# Altium schematic sheet PWR.SchDoc
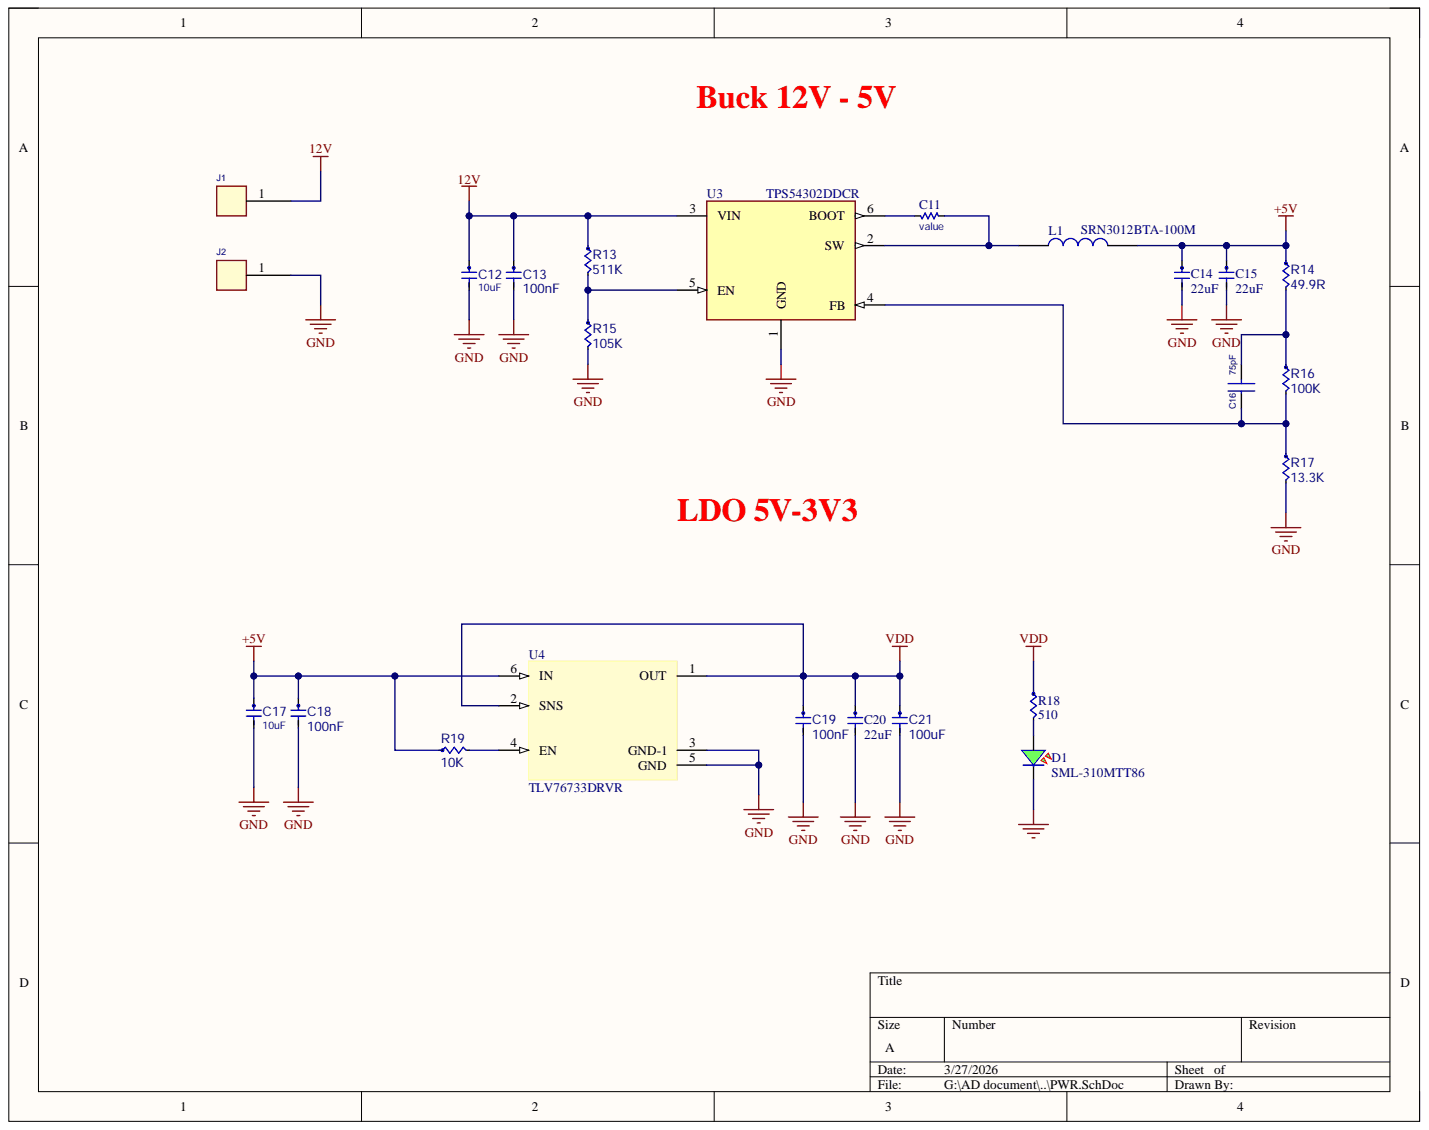
[diagram p0: PWR.SchDoc]

## Parts
C11  RES0402  [RES0402]  (value not drawn)
C12  Capacitance: 10uF  [CAP0603]  (hidden comment: GRM188R6YA106MA73D)
C13  Capacitance: 100nF  [CAP0402]  (hidden comment: CC0402KRX5R9BB104)
C14  Capacitance: 22uF  [CAP0402]  (hidden comment: GRM158R61A226ME15D)
C15  Capacitance: 22uF  [CAP0402]  (hidden comment: GRM158R61A226ME15D)
C16  75pF  [CAP0402]
C17  Capacitance: 10uF  [CAP0603]  (hidden comment: GRM188R6YA106MA73D)
C18  Capacitance: 100nF  [CAP0402]  (hidden comment: CC0402KRX5R9BB104)
C19  Capacitance: 100nF  [CAP0402]  (hidden comment: CC0402KRX5R9BB104)
C20  Capacitance: 22uF  [CAP0402]  (hidden comment: GRM158R61A226ME15D)
C21  Capacitance: 100uF  [CAP0805]  (hidden comment: CL21A107MQYNNWE)
D1  SML-310MTT86  [LED0603 RED]
J1  CON1  [ESC PAD 3X4]  (value not drawn)
J2  CON1  [ESC PAD 3X4]  (value not drawn)
L1  SRN3012BTA-100M  [SRN3012BTA-100M]
R13  511K  [RES0402]
R14  49.9R  [RES0402]
R15  105K  [RES0402]
R16  100K  [RES0402]
R17  13.3K  [RES0402]
R18  RT0402FRE07510RL  [RES0402]  (value not drawn)
R19  Resistance: 10K  [RES0402]  (hidden comment: CRCW040210K0JNED)
U3  TPS54302DDCR  [SOT95P280X110-6N - duplicate]
U4  TLV76733DRVR  [SON65P200X200X80-7N - duplicate]

## Nets
12V: C12.1 C13.1 J1.1 R13.1 U3.3(VIN)
+5V: C14.1 C15.1 C17.1 C18.1 L1.2 R14.1 R19.1 U4.6(IN)
GND: C12.2 C13.2 C14.2 C15.2 C17.2 C18.2 C19.2 C20.2 C21.2 D1.1 J2.1 R15.2 R17.2 U3.1(GND) U4.3(GND-1) U4.5(GND)
VDD: C19.1 C20.1 C21.1 R18.1 U4.1(OUT) U4.2(SNS)
NetC11_1: C11.1 U3.6(BOOT)
NetC11_2: C11.2 L1.1 U3.2(SW)
NetC16_1: C16.1 R16.2 R17.1 U3.4(FB)
NetC16_2: C16.2 R14.2 R16.1
NetD1_2: D1.2 R18.2
NetR13_2: R13.2 R15.1 U3.5(EN)
NetR19_2: R19.2 U4.4(EN)

## Library data of the parts
C11 = RES0402: RES 0402; MP: add later; Component Kind: Standard; Value: value; Library Name: RTR.SchLib
C12 C17 = CMP-01031-1: CAP CER 10UF 35V X5R 0603; Manufacturer 1: Murata Electronics; Tolerance: 20%; Case Code (Imperial): 0603; Case Code (Metric): 1608; Case/Package: 0603; Component Kind: Standard; Composition: Ceramic; Depth: 0.8mm; Dielectric: X5R; Height: 0.8mm; Lead Free: Lead Free; Length: 1.6mm; Manufacturer Part Number 1: GRM188R6YA106MA73D; Max Operating Temperature: 85°C; Min Operating Temperature: -55°C; Mount: Surface Mount; Number of Pins: 2; Package Quantity: 1; Packaging: Tape and Reel; REACH SVHC: No SVHC; Termination: SMD/SMT; Thickness: 0.031inch; Voltage: 35V; Voltage Rating: 35V; Voltage Rating (DC): 35V; Weight: 0.000222oz; Width: 0.031inch; Library Name: rtr.IntLib
C13 C18 C19 = CMP-00265-1: CAP CER 0.1UF 50V X5R 0402; Manufacturer: Yageo; Tolerance: 10%; Case/Package: 0402; Component Kind: Standard; Dielectric: X5R; Halogen Free: Halogen Free; Lead Free: Lead Free; Length: 0.039inch; Manufacturer 1: Yageo; Manufacturer Part Number: CC0402KRX5R9BB104; Manufacturer Part Number 1: CC0402KRX5R9BB104; Max Operating Temperature: 85°C; Min Operating Temperature: -55°C; Mount: Surface Mount; Packaging: Tape and Reel; Thickness: 0.022inch; Voltage Rating: 50V; Voltage Rating (DC): 50V; Width: 0.02inch; Library Name: rtr.IntLib
C14 C15 C20 = CMP-00520-1: CAP CER 22UF 10V X5R 0402; Manufacturer 1: TDK Corporation; Tolerance: 10%; Case Code (Imperial): 0402; Case Code (Metric): 1005; Case/Package: 0402; Component Kind: Standard; Depth: 0.5mm; Dielectric: X5R; Length: 1mm; Library Name: CH961_CARRIER.IntLib; Manufacturer Part Number 1: GRM158R61A226ME15D; Max Operating Temperature: 85°C; Min Operating Temperature: -55°C; Packaging: Tape and Reel; Radiation Hardening: No; REACH SVHC: No SVHC; Termination: SMD/SMT; Voltage: 10V; Voltage Rating: 10V
C16 = CAP_Dup1_16: CAP CER 75PF 25V C0G/NP0 0402; Manufacturer: YAGEO; Manufacturer PN: CC0402JRNPO9BN750; PART_NUMBER: TMP-416; CLASS: DISCRETE; Dielectric Type: Capacitors; Temperature Coefficient: C0G, NP0; Tolerance: 5%; Component Kind: Standard; Library Name: motor MB1419.IntLib; Package Size: 0402 (1005 Metric); Rated Voltage: 25V
C21 = CMP-00446-1: CAP CER 100UF 6.3V X5R 0805; Manufacturer 1: TDK Corporation; Dielectric Material: Ceramic; Tolerance: 20%; Case Code (Imperial): 0805; Case Code (Metric): 2012; Case/Package: 0805; Component Kind: Standard; Composition: Ceramic; Depth: 1.25mm; Dielectric: X5R; Height: 1.25mm; Lead Free: Lead Free; Length: 2mm; Manufacturer Part Number 1: CL21A107MQYNNWE; Max Operating Temperature: 85°C; Min Operating Temperature: -55°C; Mount: Surface Mount; Package Quantity: 2000; Packaging: Tape and Reel; REACH SVHC: No SVHC; Termination: SMD/SMT; Thickness: 0.057inch; Voltage Rating: 6.3V; Voltage Rating (DC): 6.3V; Weight: 0.000194oz; Width: 0.049inch; Library Name: rtr.IntLib
D1 = CMP-01130-2: LED GREEN CLEAR 0603 SMD; Manufacturer 1: Rohm Semiconductor; Color: Green,Yellow; Dominant Wavelength: 570nm; Forward Current: 20mA; Forward Voltage: 2.2V; Lens Color: Colorless,Clear; Lens Style: Rectangular,Clear,Diffused,Colorless; Luminous Intensity: 16mcd; Number of LEDs: 1; Viewing Angle: 140°; Case Code (Imperial): 0603; Case Code (Metric): 1608; Case/Package: 0603; Component Kind: Standard; Height: 0.9mm; Illumination Color: Green; Lead Free: Lead Free; Length: 1.6mm; Lens Transparency: Clear; Manufacturer Part Number 1: SML-310MTT86; Max Operating Temperature: 85°C; Min Operating Temperature: -30°C; Mount: Surface Mount; Number of Pins: 2; Package Quantity: 3000; Packaging: Tape and Reel; Radiation Hardening: No; Test Current: 20mA; Wavelength: 570nm; Width: 0.8mm; Library Name: HeraJetson_Modified.IntLib
J1 J2 = CON1: SOLDER PADS; Component Kind: Standard; Library Name: motor MB1419.IntLib
L1 = SRN3012BTA-330M: 10uH; Manufacturer_Name: Bourns; Geometry.Height: 1.2mm; Component Kind: Standard; Datasheet Link: https://www.bourns.com/docs/Product-Datasheets/SRN3012BTA.pdf; Library Name: SRN3012BTA-330M.SchLib; Manufacturer_Part_Number: SRN3012BTA-100M; Mouser Part Number: SRN3012BTA-100M; Mouser Price/Stock: https://www.mouser.co.uk/ProductDetail/Bourns/SRN3012BTA-330M?qs=Imq1NPwxi74rPoSZ4PPaRw%3D%3D
R13 = CMP-00299-1_1: RES SMD 511K OHM 1% 1/16W 0402; Manufacturer: Yageo; Manufacturer Part Number: RC0402FR-07511KL; Max Power Dissipation: 63mW; Power Rating: 62.5mW; Temperature Coefficient: 100ppm/°C; Voltage Rating: 50V; Case Code (Imperial): 0402; Case Code (Metric): 1005; Case/Package: 0402; Component Kind: Standard; Composition: Thick Film; Depth: 0.5mm; Features: Moisture Resistant; Height: 0.016inch; Lead Free: Lead Free; Length: 1mm; Manufacturer 1: Yageo; Manufacturer Part Number 1: RC0402FR-07511KL; Max Operating Temperature: 155°C; Military Standard: Not; Min Operating Temperature: -55°C; Number of Terminations: 2; Package Quantity: 1; Packaging: Tape and Reel; Resistance: 511K; Termination: SMD/SMT; Tolerance: 1%; Voltage Rating (DC): 50V; Width: 0.02inch; Working Voltage: 50V; Library Name: rtr.IntLib
R14 = CMP-00299-1_1: RES SMD 49.9R OHM 1% 1/16W 0402; Manufacturer: Yageo; Manufacturer Part Number: RC0402FR-0749R9L; Max Power Dissipation: 63mW; Power Rating: 62.5mW; Temperature Coefficient: 100ppm/°C; Voltage Rating: 50V; Case Code (Imperial): 0402; Case Code (Metric): 1005; Case/Package: 0402; Component Kind: Standard; Composition: Thick Film; Depth: 0.5mm; Features: Moisture Resistant; Height: 0.016inch; Lead Free: Lead Free; Length: 1mm; Manufacturer 1: Yageo; Manufacturer Part Number 1: RC0402FR-0749R9L; Max Operating Temperature: 155°C; Military Standard: Not; Min Operating Temperature: -55°C; Number of Terminations: 2; Package Quantity: 1; Packaging: Tape and Reel; Resistance: 49.9R; Termination: SMD/SMT; Tolerance: 1%; Voltage Rating (DC): 50V; Width: 0.02inch; Working Voltage: 50V; Library Name: rtr.IntLib
R15 = CMP-00299-1_1: RES SMD 105K OHM 1% 1/16W 0402; Manufacturer: Yageo; Manufacturer Part Number: RC0402FR-07105KL; Max Power Dissipation: 63mW; Power Rating: 62.5mW; Temperature Coefficient: 100ppm/°C; Voltage Rating: 50V; Case Code (Imperial): 0402; Case Code (Metric): 1005; Case/Package: 0402; Component Kind: Standard; Composition: Thick Film; Depth: 0.5mm; Features: Moisture Resistant; Height: 0.016inch; Lead Free: Lead Free; Length: 1mm; Manufacturer 1: Yageo; Manufacturer Part Number 1: RC0402FR-07105KL; Max Operating Temperature: 155°C; Military Standard: Not; Min Operating Temperature: -55°C; Number of Terminations: 2; Package Quantity: 1; Packaging: Tape and Reel; Resistance: 105K; Termination: SMD/SMT; Tolerance: 1%; Voltage Rating (DC): 50V; Width: 0.02inch; Working Voltage: 50V; Library Name: rtr.IntLib
R16 = CMP-00299-1_1: RES SMD 100K OHM 1% 1/16W 0402; Manufacturer: Yageo; Manufacturer Part Number: RC0402FR-07100KL; Max Power Dissipation: 63mW; Power Rating: 62.5mW; Temperature Coefficient: 100ppm/°C; Voltage Rating: 50V; Case Code (Imperial): 0402; Case Code (Metric): 1005; Case/Package: 0402; Component Kind: Standard; Composition: Thick Film; Depth: 0.5mm; Features: Moisture Resistant; Height: 0.016inch; Lead Free: Lead Free; Length: 1mm; Manufacturer 1: Yageo; Manufacturer Part Number 1: RC0402FR-07100KL; Max Operating Temperature: 155°C; Military Standard: Not; Min Operating Temperature: -55°C; Number of Terminations: 2; Package Quantity: 1; Packaging: Tape and Reel; Resistance: 100K; Termination: SMD/SMT; Tolerance: 1%; Voltage Rating (DC): 50V; Width: 0.02inch; Working Voltage: 50V; Library Name: rtr.IntLib
R17 = CMP-00299-1_1: RES SMD 13.3K OHM 1% 1/16W 0402; Manufacturer: Yageo; Manufacturer Part Number: RC0402FR-0713K3L; Max Power Dissipation: 63mW; Power Rating: 62.5mW; Temperature Coefficient: 100ppm/°C; Voltage Rating: 50V; Case Code (Imperial): 0402; Case Code (Metric): 1005; Case/Package: 0402; Component Kind: Standard; Composition: Thick Film; Depth: 0.5mm; Features: Moisture Resistant; Height: 0.016inch; Lead Free: Lead Free; Length: 1mm; Manufacturer 1: Yageo; Manufacturer Part Number 1: RC0402FR-0713K3L; Max Operating Temperature: 155°C; Military Standard: Not; Min Operating Temperature: -55°C; Number of Terminations: 2; Package Quantity: 1; Packaging: Tape and Reel; Resistance: 13.3K; Termination: SMD/SMT; Tolerance: 1%; Voltage Rating (DC): 50V; Width: 0.02inch; Working Voltage: 50V; Library Name: rtr.IntLib
R18 = RC0402FR-0722KL: RES SMD 510 OHM 1% 1/16W 0402; Manufacturer: Yageo; Max Power Dissipation: 63mW; Power Rating: 62.5mW; Resistance: 510; Temperature Coefficient: 100ppm/°C; Voltage Rating: 50V; Case Code (Imperial): 0402; Case Code (Metric): 1005; Case/Package: 0402; Category: Chip SMD Resistors; Component Kind: Standard; Construction: Rectangular; Depth: 0.5mm; Features: Moisture Resistant; Height: 0.016inch; Lead Free: Lead Free; Length: 0.039inch; Library Name: CH961_CARRIER.IntLib; Manufacturer Part Number: RT0402FRE07510RL; Max Operating Temperature: 155°C; Military Standard: Not; Min Operating Temperature: -55°C; Number of Terminations: 2; Package Quantity: 1; Packaging: Tape and Reel; Pricing 1: 10000=0.00100, 50000=0.00090, 300000=0.00080, 1000000=0.00080, 3000000=0.00070 (USD); Reach SVHC Compliant: Yes; Resistance Tolerance: 1%; Resistor Type: Thick Film; RoHS: Yes; RoHS Compliant: Yes; Series: RC; Stock 1: 1010000; Supplier 1: Future Electronics; Termination: SMD/SMT; Tolerance: 1%; Width: 0.02inch; Working Voltage: 50V
R19 = CMP-00034-1: RES SMD 10K OHM 5% 1/16W 0402; Manufacturer: Vishay Dale; Max Power Dissipation: 63mW; Temperature Coefficient: 200ppm/°C; Voltage Rating: 50V; Case Code (Imperial): 0402; Case Code (Metric): 1005; Case/Package: 0402; Component Kind: Standard; Composition: Thick Film; Contact Plating: Tin; Depth: 0.5mm; Features: Automotive AEC-Q200; Height: 0.016inch; Length: 1mm; Manufacturer Part Number 1: CRCW040210K0JNED; Max Operating Temperature: 155°C; Military Standard: Not; Min Operating Temperature: -55°C; Mount: Surface Mount; Number of Terminations: 2; Package Quantity: 1; Packaging: Tape and Reel; Power Rating: 63mW; Radiation Hardening: No; REACH SVHC: Unknown; Termination: SMD/SMT; Tolerance: 5%; Weight: 2.3E-05oz; Width: 0.5mm; Library Name: HeraJetson_Modified.IntLib
U3 = TPS54302DDCR: 4.5-V to 28-V Input, 3-A Output, EMI Friendly Synchronous Step-Down Converter; MF: Texas Instruments; Availability: In Stock; Check_prices: https://www.snapeda.com/parts/TPS54302DDCR/Texas+Instruments/view-part/?ref=eda; Component Kind: Standard; MP: TPS54302DDCR; Package: SOT-23-THN-6 Texas Instruments; Price: None; Purchase-URL: https://pricing.snapeda.com/search?q=TPS54302DDCR&ref=eda; SnapEDA_Link: https://www.snapeda.com/parts/TPS54302DDCR/Texas+Instruments/view-part/?ref=snap; Library Name: RTR.SchLib
U4 = TLV76733DRVR: Adjustable- and fixed-output, 1-A, 16-V, positive-voltage low-dropout (LDO) linear regulator; MF: Texas Instruments; Check_prices: https://www.snapeda.com/parts/TLV76733DRVR/Texas+Instruments/view-part/?ref=eda; Component Kind: Standard; Library Name: TLV76733DRVR.IntLib; MP: TLV76733DRVR; Package: WSON-6 Texas Instruments; SnapEDA_Link: https://www.snapeda.com/parts/TLV76733DRVR/Texas+Instruments/view-part/?ref=snap
